# Altium schematic sheet P15-EXP_IO.SchDoc
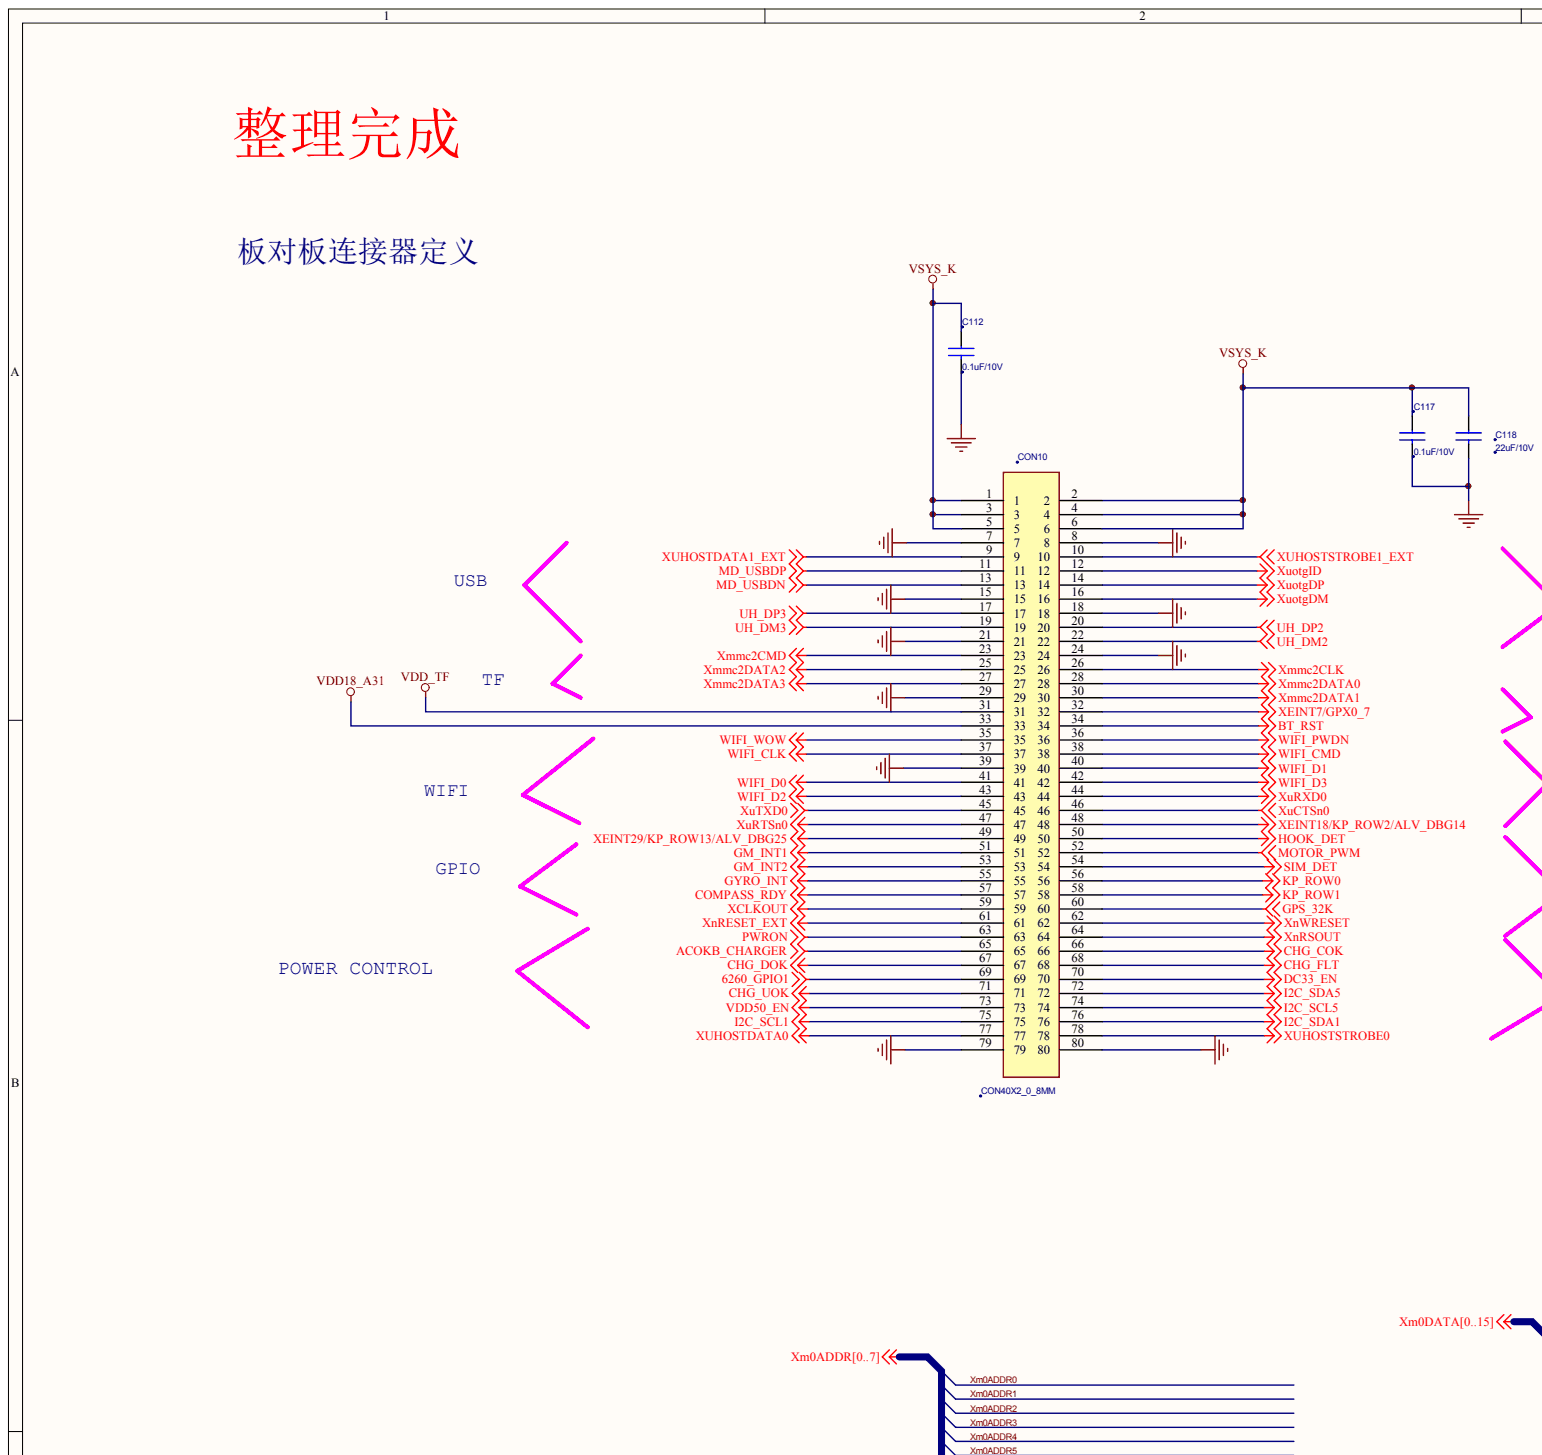
[diagram p0: P15-EXP_IO.SchDoc, top left]
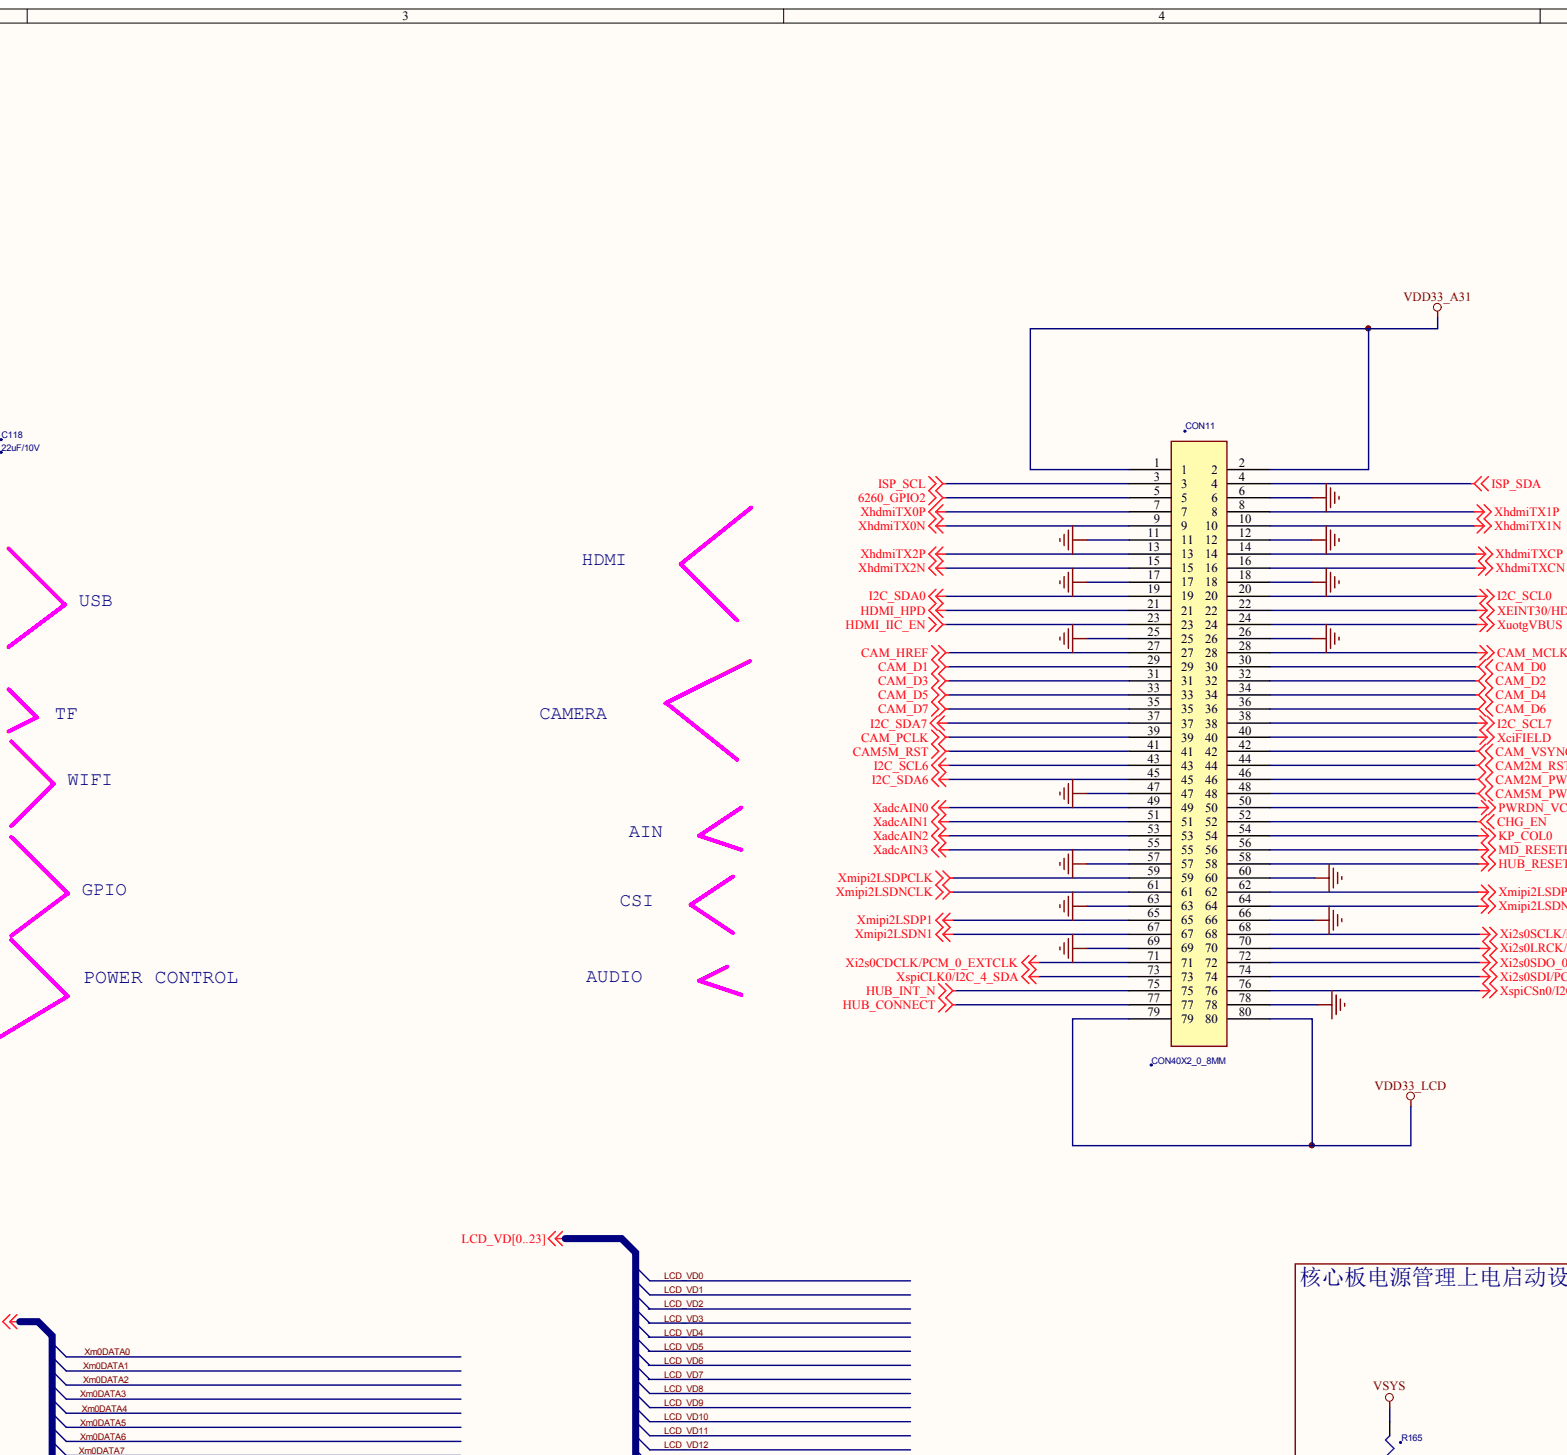
[diagram p1: P15-EXP_IO.SchDoc, top centre]
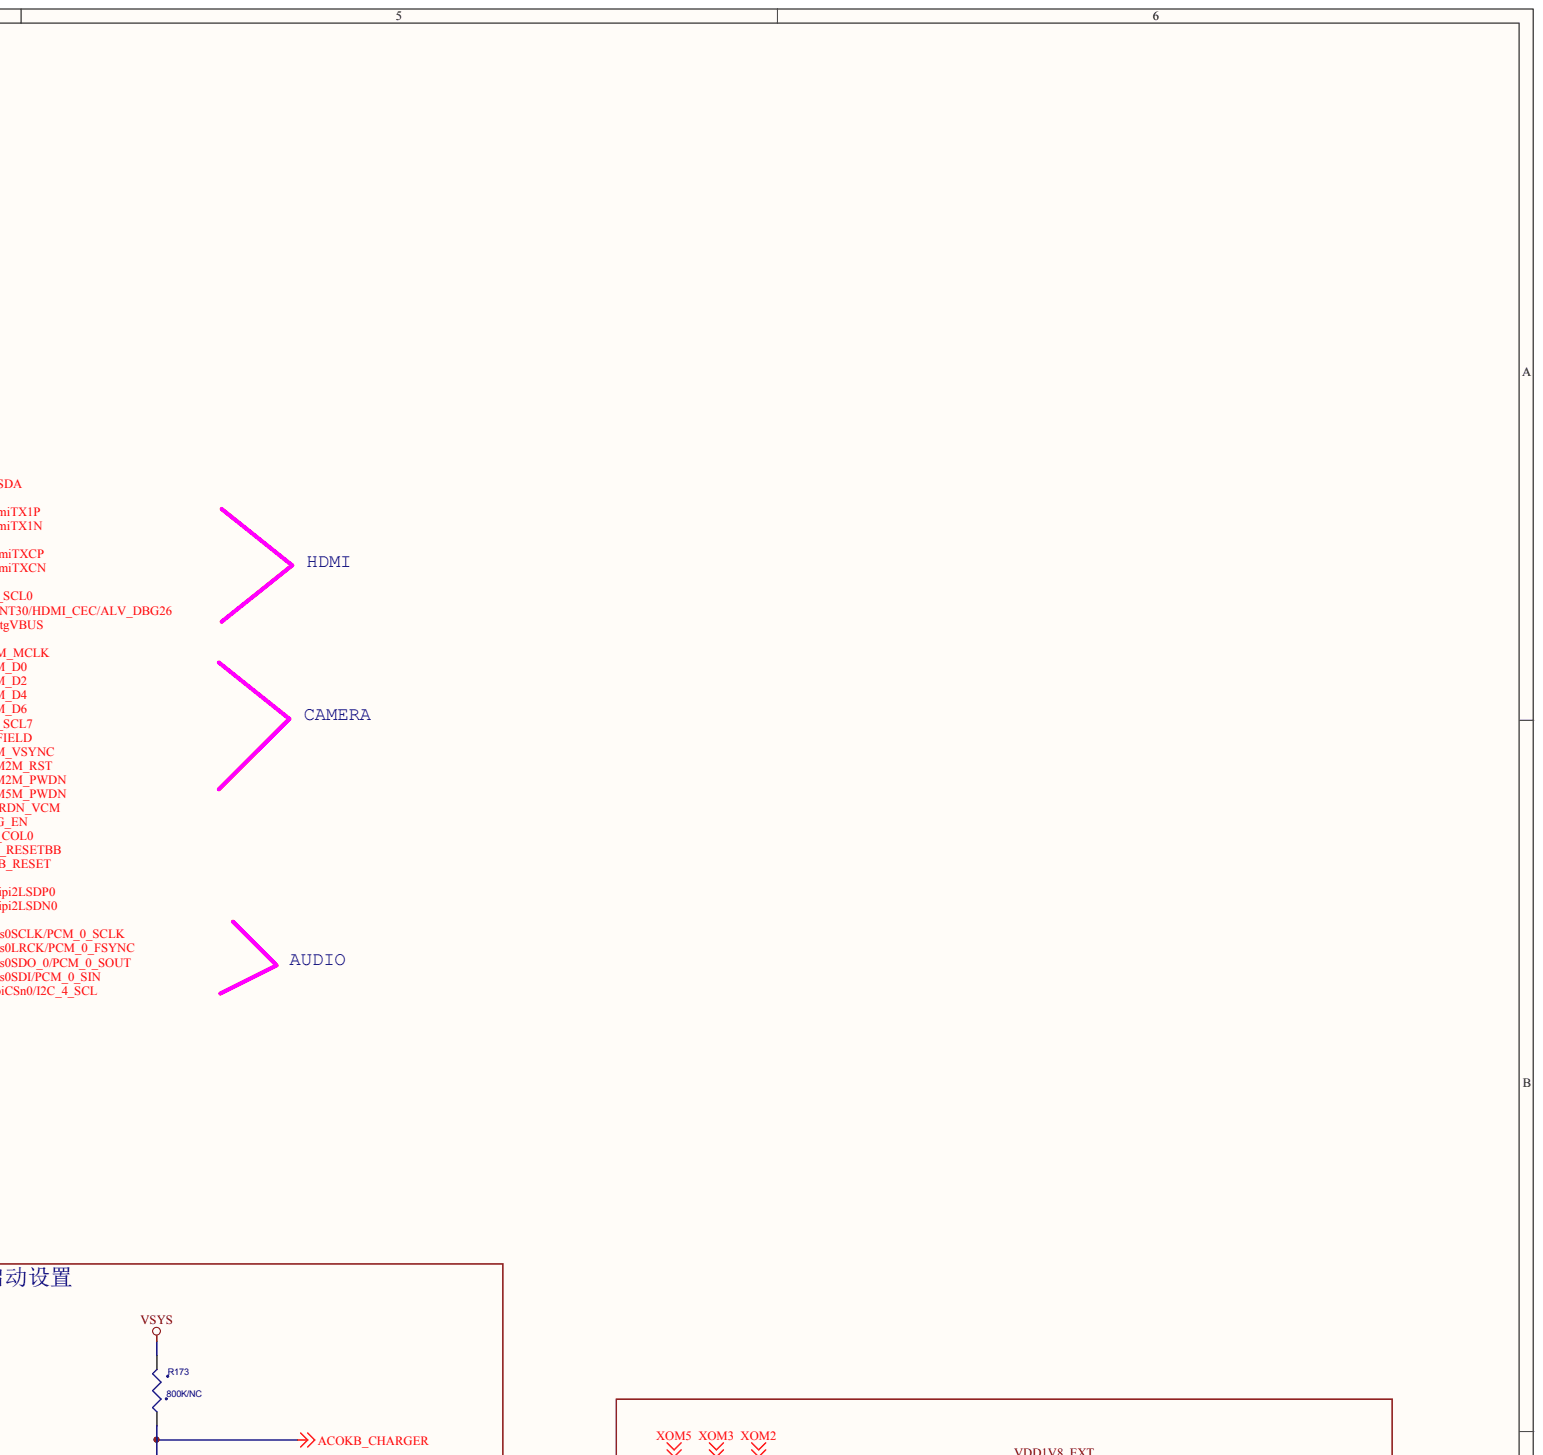
[diagram p2: P15-EXP_IO.SchDoc, top right]
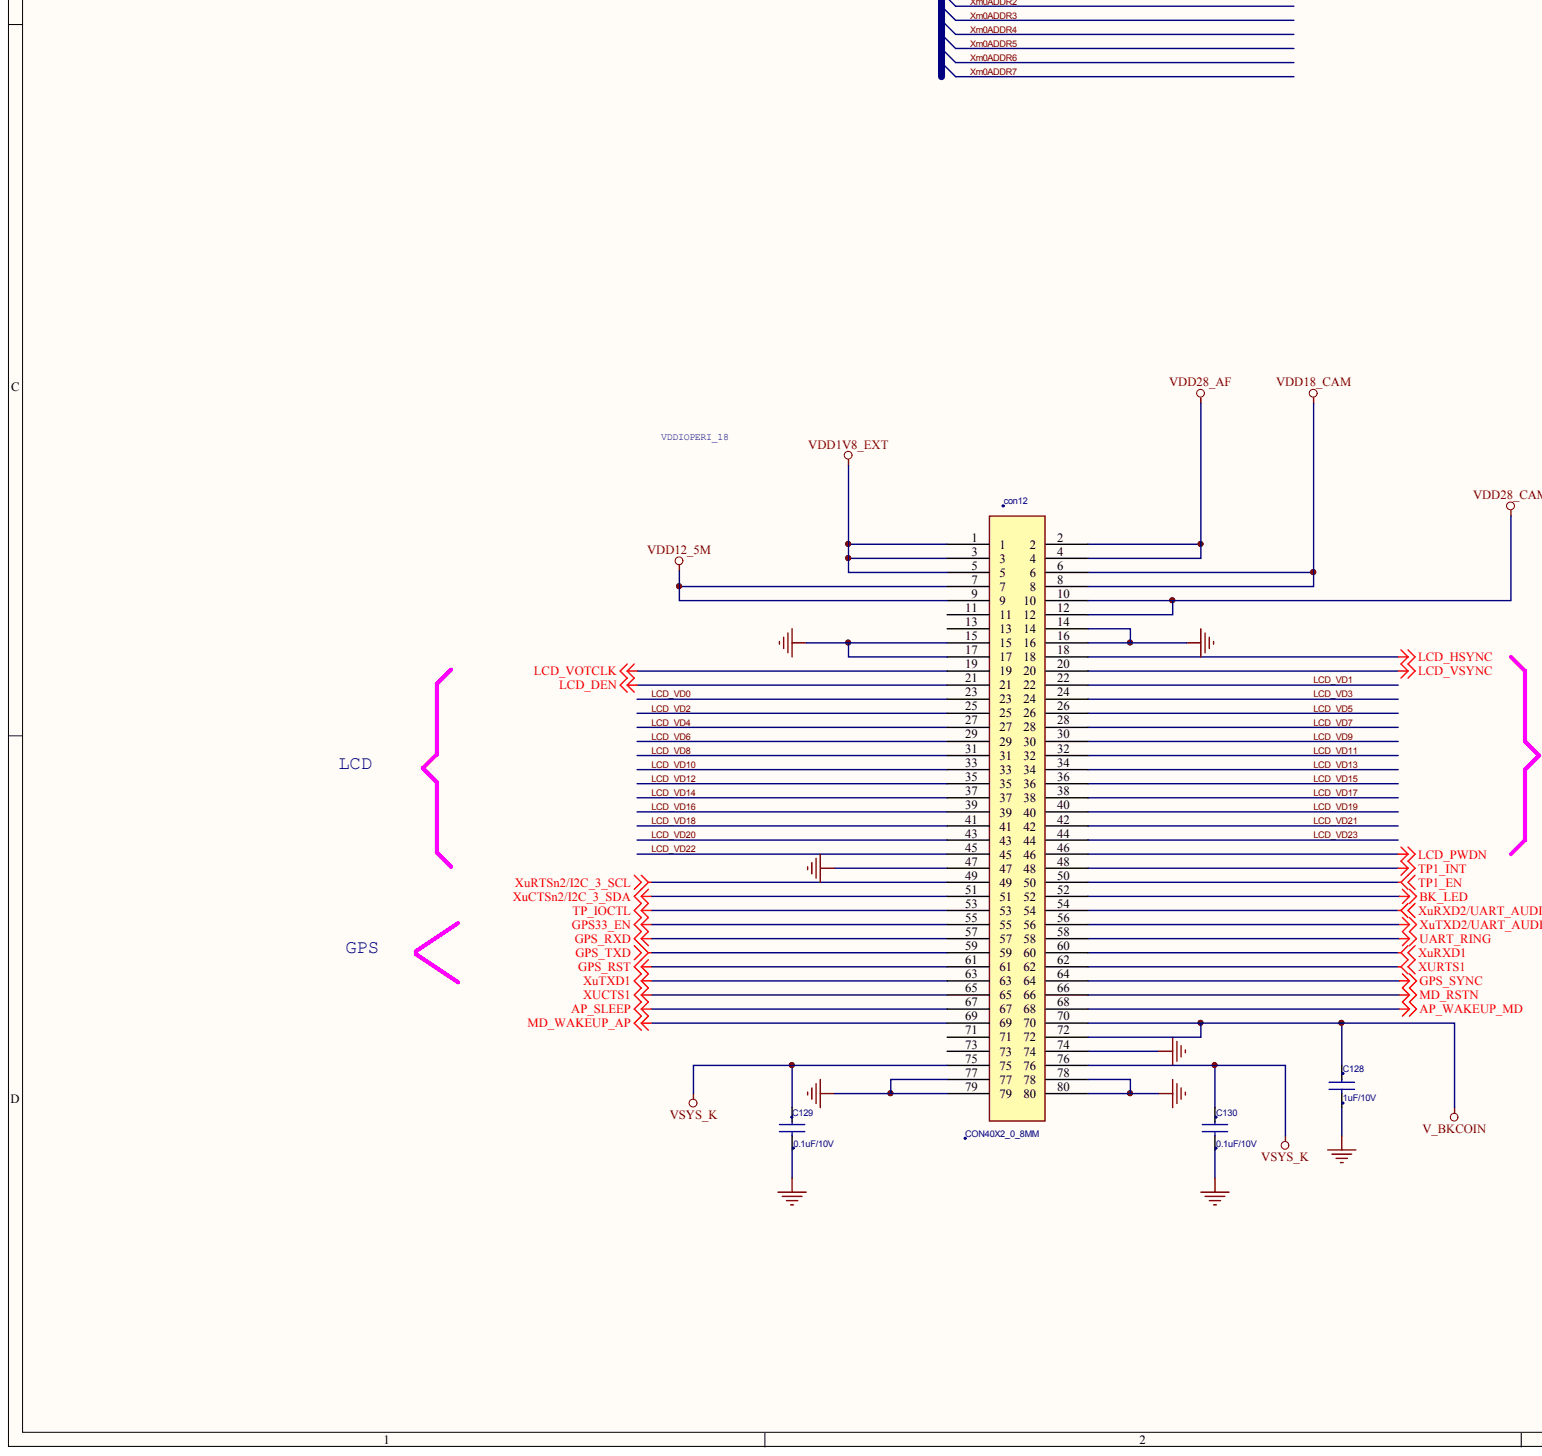
[diagram p3: P15-EXP_IO.SchDoc, bottom left]
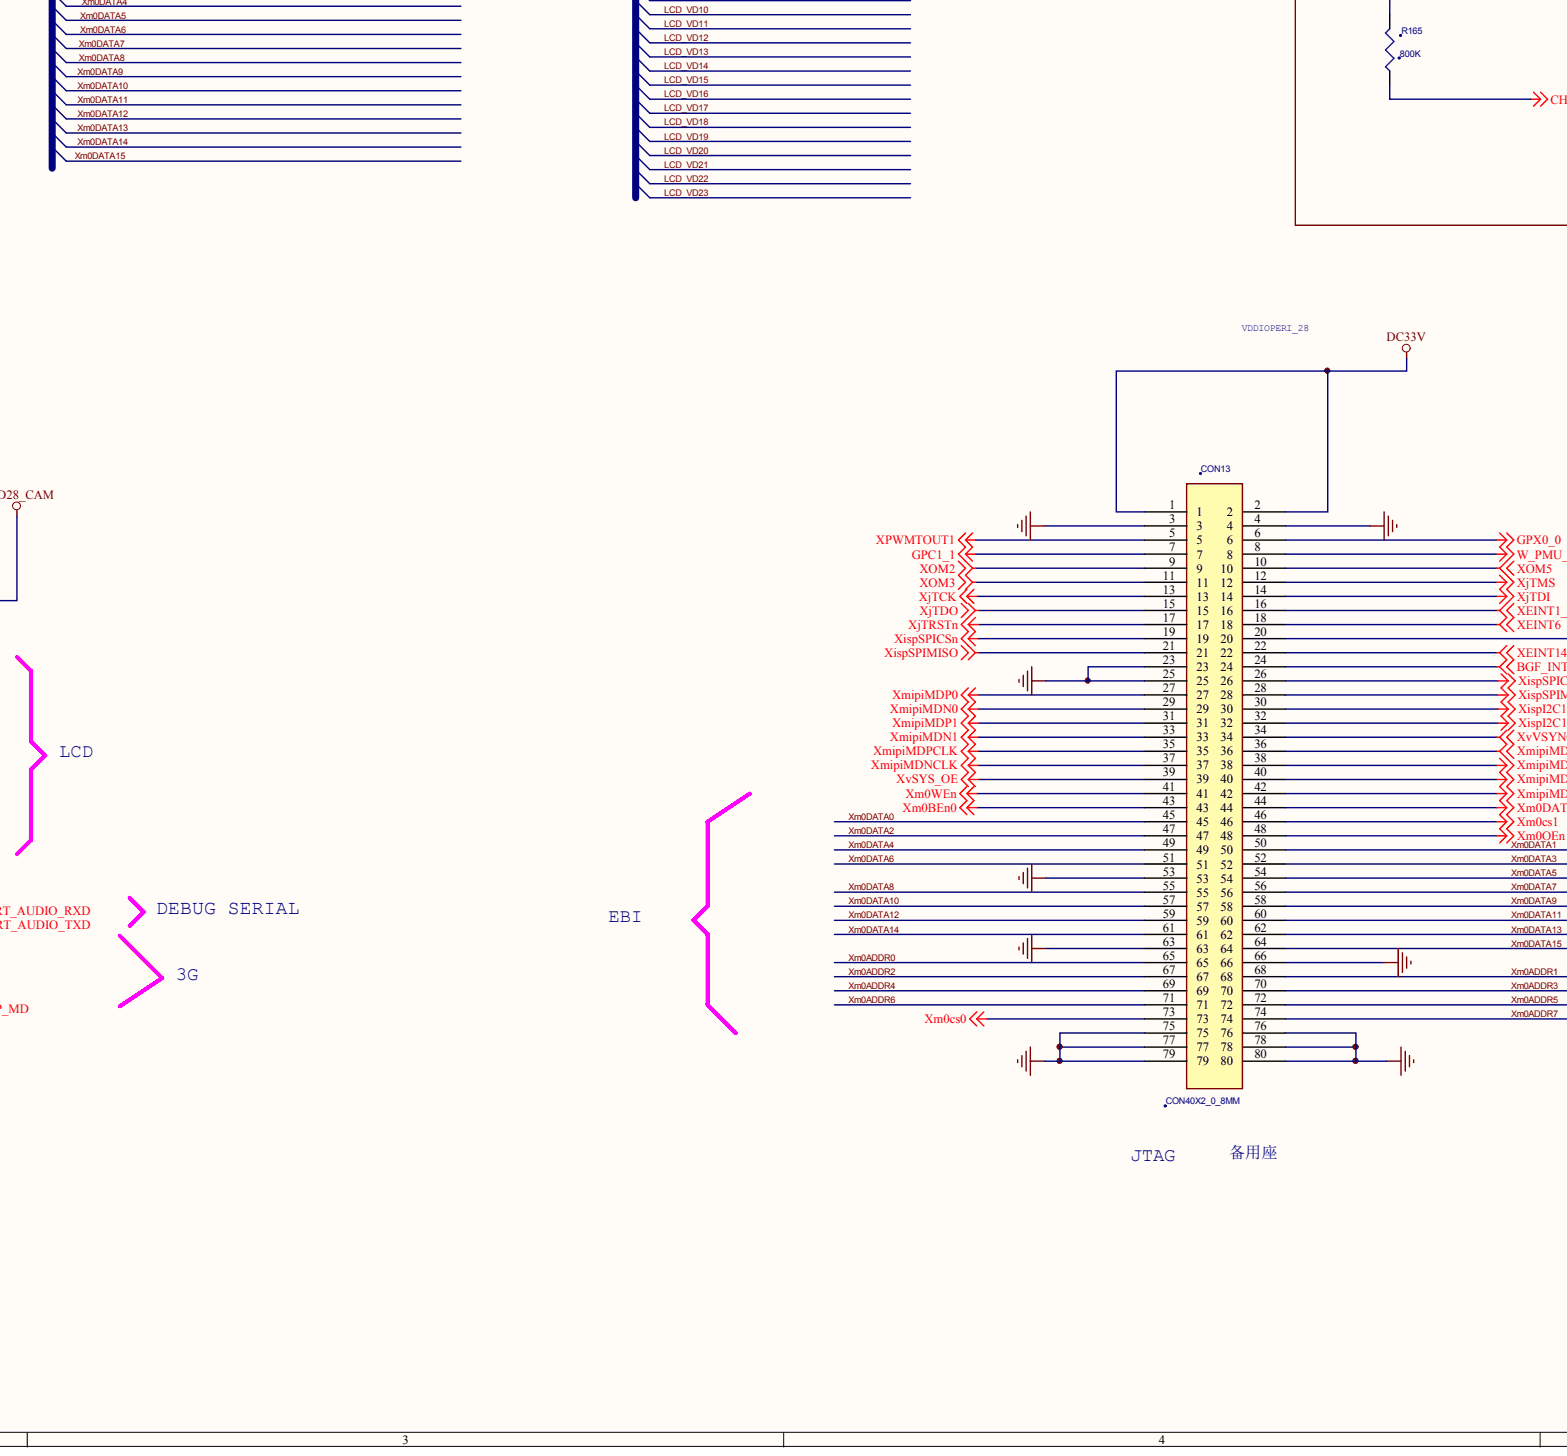
[diagram p4: P15-EXP_IO.SchDoc, bottom centre]
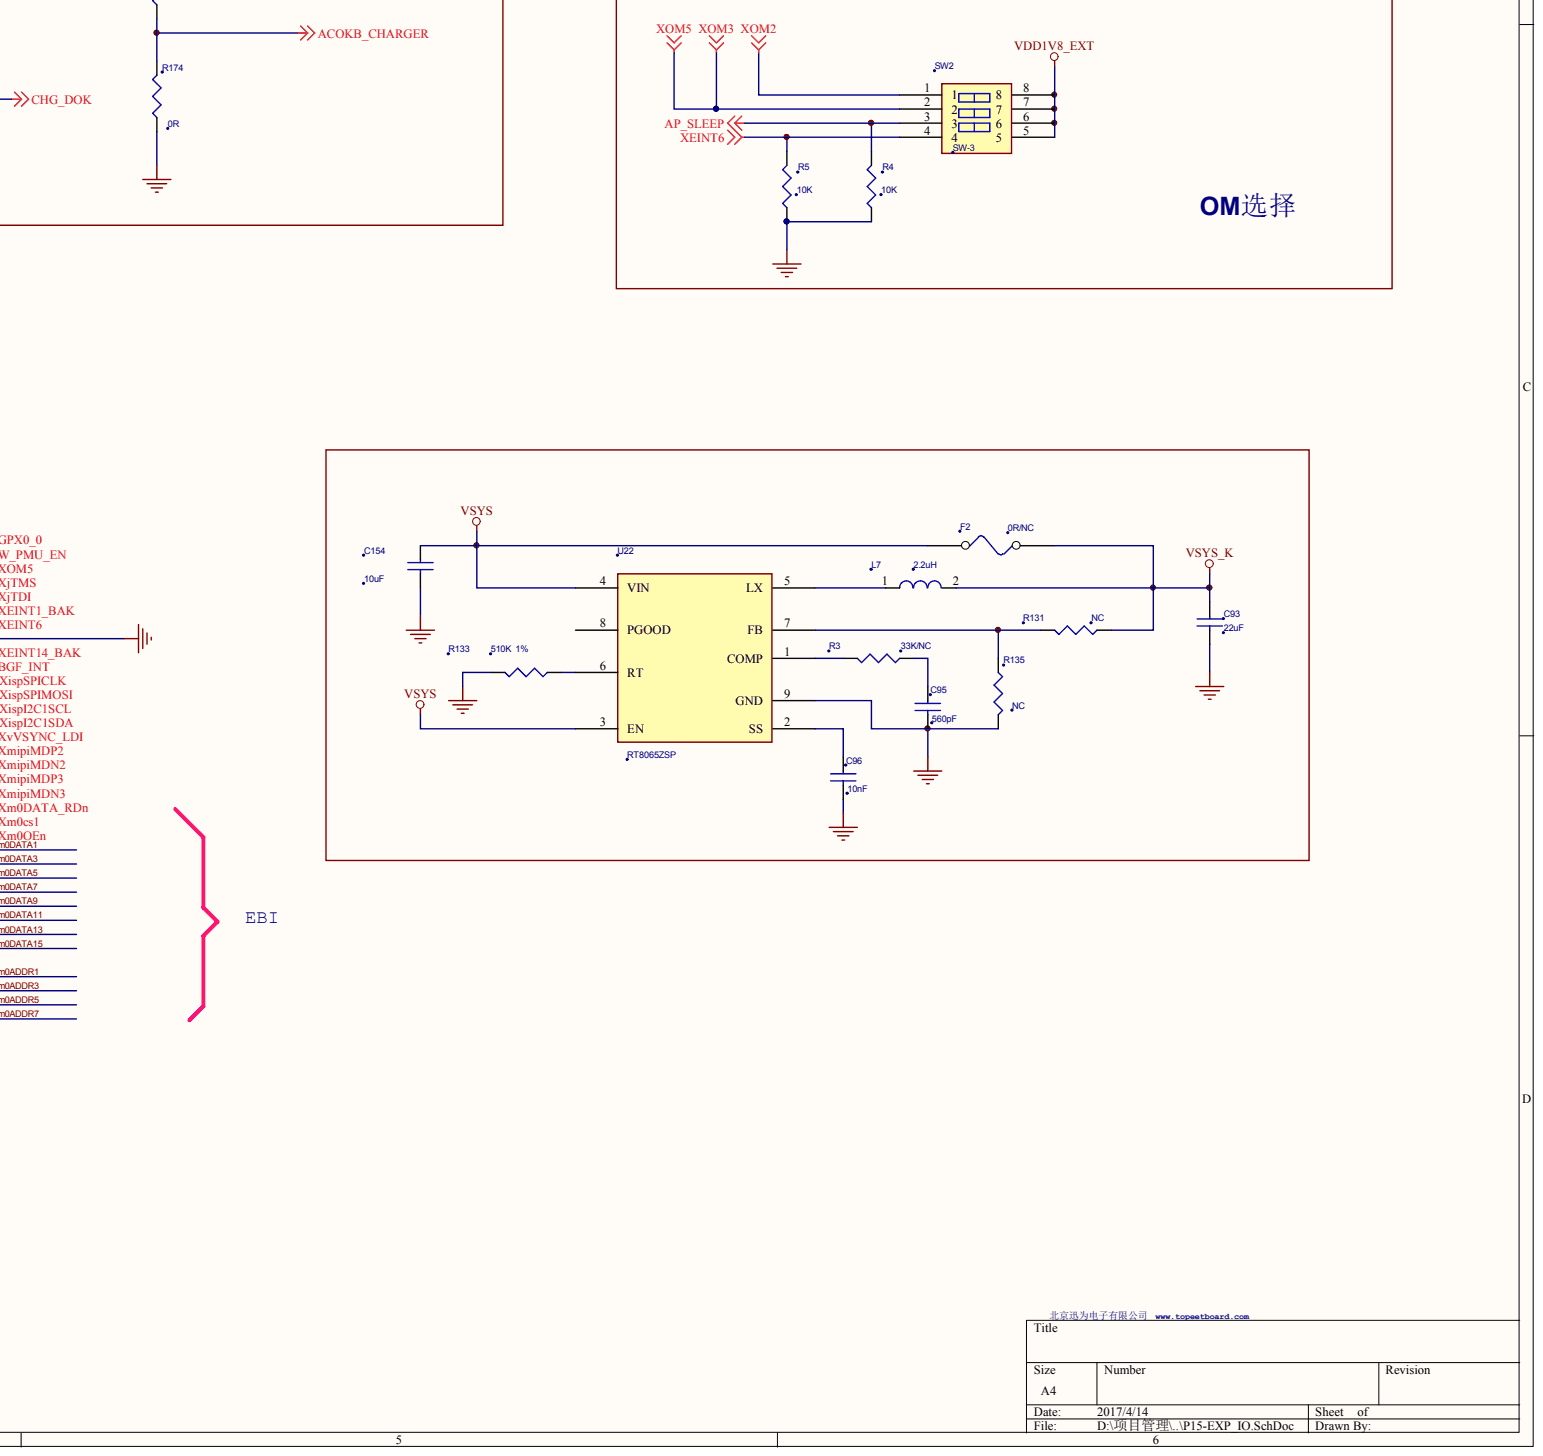
[diagram p5: P15-EXP_IO.SchDoc, bottom right]

## Parts
C93  22uF
C95  560pF
C96  10nF
C112  0.1uF/10V
C117  0.1uF/10V
C118  22uF/10V
C128  1uF/10V
C129  0.1uF/10V
C130  0.1uF/10V
C154  10uF
CON10  CON40X2_0_8MM  (value not drawn)
CON11  CON40X2_0_8MM  (value not drawn)
con12  CON40X2_0_8MM  (value not drawn)
CON13  CON40X2_0_8MM  (value not drawn)
F2  0R/NC
L7  2.2uH
R3  33K/NC
R4  10K
R5  10K
R131  NC
R133  510K  1%
R135  NC
R165  800K
R173  800K/NC
R174  0R
SW2  SW-3
U22  RT8065ZSP

## Nets
6260_GPIO1: CON10.69
6260_GPIO2: CON11.5
ACOKB_CHARGER: CON10.65 R173.1 R174.2
AP_SLEEP: con12.67 R4.2 SW2.3
AP_WAKEUP_MD: con12.68
BGF_INT: CON13.24
BK_LED: con12.52
BT_RST: CON10.34
CAM2M_PWDN: CON11.46
CAM2M_RST: CON11.44
CAM5M_PWDN: CON11.48
CAM5M_RST: CON11.41
CAM_D0: CON11.30
CAM_D1: CON11.29
CAM_D2: CON11.32
CAM_D3: CON11.31
CAM_D4: CON11.34
CAM_D5: CON11.33
CAM_D6: CON11.36
CAM_D7: CON11.35
CAM_HREF: CON11.27
CAM_MCLK: CON11.28
CAM_PCLK: CON11.39
CAM_VSYNC: CON11.42
CHG_COK: CON10.66
CHG_DOK: CON10.67 R165.1
CHG_EN: CON11.52
CHG_FLT: CON10.68
CHG_UOK: CON10.71
COMPASS_RDY: CON10.57
DC33_EN: CON10.70
DC33V: CON13.1 CON13.2
GM_INT1: CON10.51
GM_INT2: CON10.53
GND: C93.2 C95.2 C96.2 C112.2 C117.2 C118.2 C128.2 C129.2 C130.2 C154.2 CON10.7 CON10.8 CON10.15 CON10.18 CON10.21 CON10.24 CON10.29 CON10.39 CON10.79 CON10.80 CON11.6 CON11.11 CON11.12 CON11.17 CON11.18 CON11.25 CON11.26 CON11.47 CON11.57 CON11.60 CON11.63 CON11.66 CON11.69 CON11.78 con12.14 con12.15 con12.16 con12.17 con12.47 con12.74 con12.77 con12.78 con12.79 con12.80 CON13.3 CON13.4 CON13.20 CON13.23 CON13.25 CON13.53 CON13.63 CON13.66 CON13.75 CON13.76 CON13.77 CON13.78 CON13.79 CON13.80 R4.1 R5.1 R133.2 R135.2 R174.1 U22.9(GND)
GPC1_1: CON13.7
GPS33_EN: con12.55
GPS_32K: CON10.60
GPS_RST: con12.61
GPS_RXD: con12.57
GPS_SYNC: con12.64
GPS_TXD: con12.59
GPX0_0: CON13.6
GYRO_INT: CON10.55
HDMI_HPD: CON11.21
HDMI_IIC_EN: CON11.23
HOOK_DET: CON10.50
HUB_CONNECT: CON11.77
HUB_INT_N: CON11.75
HUB_RESET: CON11.58
I2C_SCL0: CON11.20
I2C_SCL1: CON10.75
I2C_SCL5: CON10.74
I2C_SCL6: CON11.43
I2C_SCL7: CON11.38
I2C_SDA0: CON11.19
I2C_SDA1: CON10.76
I2C_SDA5: CON10.72
I2C_SDA6: CON11.45
I2C_SDA7: CON11.37
ISP_SCL: CON11.3
ISP_SDA: CON11.4
KP_COL0: CON11.54
KP_ROW0: CON10.56
KP_ROW1: CON10.58
LCD_DEN: con12.21
LCD_HSYNC: con12.18
LCD_PWDN: con12.46
LCD_VOTCLK: con12.19
LCD_VSYNC: con12.20
MD_RESETBB: CON11.56
MD_RSTN: con12.66
MD_USBDN: CON10.13
MD_USBDP: CON10.11
MD_WAKEUP_AP: con12.69
MOTOR_PWM: CON10.52
PWRDN_VCM: CON11.50
PWRON: CON10.63
SIM_DET: CON10.54
TP1_EN: con12.50
TP1_INT: con12.48
TP_IOCTL: con12.53
UART_RING: con12.58
UH_DM2: CON10.22
UH_DM3: CON10.19
UH_DP2: CON10.20
UH_DP3: CON10.17
V_BKCOIN: C128.1 con12.70 con12.72
VDD1V8_EXT: con12.1 con12.3 con12.5 SW2.5 SW2.6 SW2.7 SW2.8
VDD12_5M: con12.7 con12.9
VDD18_A31: CON10.33
VDD18_CAM: con12.6 con12.8
VDD28_AF: con12.2 con12.4
VDD28_CAM: con12.10 con12.12
VDD33_A31: CON11.1 CON11.2
VDD33_LCD: CON11.79 CON11.80
VDD50_EN: CON10.73
VDD_TF: CON10.31
VSYS: C154.1 F2.1 R165.2 R173.2 U22.3(EN) U22.4(VIN)
VSYS_K: C93.1 C112.1 C117.1 C118.1 C129.1 C130.1 CON10.1 CON10.2 CON10.3 CON10.4 CON10.5 CON10.6 con12.75 con12.76 F2.2 L7.2 R131.1
W_PMU_EN: CON13.8
WIFI_CLK: CON10.37
WIFI_CMD: CON10.38
WIFI_D0: CON10.41
WIFI_D1: CON10.40
WIFI_D2: CON10.43
WIFI_D3: CON10.42
WIFI_PWDN: CON10.36
WIFI_WOW: CON10.35
XADCAIN0: CON11.49
XADCAIN1: CON11.51
XADCAIN2: CON11.53
XADCAIN3: CON11.55
XCIFIELD: CON11.40
XCLKOUT: CON10.59
XEINT1_BAK: CON13.16
XEINT6: CON13.18 R5.2 SW2.4
XEINT7/GPX0_7: CON10.32
XEINT14_BAK: CON13.22
XEINT18/KP_ROW2/ALV_DBG14: CON10.48
XEINT29/KP_ROW13/ALV_DBG25: CON10.49
XEINT30/HDMI_CEC/ALV_DBG26: CON11.22
XHDMITX0N: CON11.9
XHDMITX0P: CON11.7
XHDMITX1N: CON11.10
XHDMITX1P: CON11.8
XHDMITX2N: CON11.15
XHDMITX2P: CON11.13
XHDMITXCN: CON11.16
XHDMITXCP: CON11.14
XI2S0CDCLK/PCM_0_EXTCLK: CON11.71
XI2S0LRCK/PCM_0_FSYNC: CON11.70
XI2S0SCLK/PCM_0_SCLK: CON11.68
XI2S0SDI/PCM_0_SIN: CON11.74
XI2S0SDO_0/PCM_0_SOUT: CON11.72
XISPI2C1SCL: CON13.30
XISPI2C1SDA: CON13.32
XISPSPICLK: CON13.26
XISPSPICSN: CON13.19
XISPSPIMISO: CON13.21
XISPSPIMOSI: CON13.28
XJTCK: CON13.13
XJTDI: CON13.14
XJTDO: CON13.15
XJTMS: CON13.12
XJTRSTN: CON13.17
XM0BEN0: CON13.43
XM0CS0: CON13.73
XM0CS1: CON13.46
XM0DATA_RDN: CON13.44
XM0OEN: CON13.48
XM0WEN: CON13.41
XMIPI2LSDN0: CON11.64
XMIPI2LSDN1: CON11.67
XMIPI2LSDNCLK: CON11.61
XMIPI2LSDP0: CON11.62
XMIPI2LSDP1: CON11.65
XMIPI2LSDPCLK: CON11.59
XMIPIMDN0: CON13.29
XMIPIMDN1: CON13.33
XMIPIMDN2: CON13.38
XMIPIMDN3: CON13.42
XMIPIMDNCLK: CON13.37
XMIPIMDP0: CON13.27
XMIPIMDP1: CON13.31
XMIPIMDP2: CON13.36
XMIPIMDP3: CON13.40
XMIPIMDPCLK: CON13.35
XMMC2CLK: CON10.26
XMMC2CMD: CON10.23
XMMC2DATA0: CON10.28
XMMC2DATA1: CON10.30
XMMC2DATA2: CON10.25
XMMC2DATA3: CON10.27
XNRESET_EXT: CON10.61
XNRSOUT: CON10.64
XNWRESET: CON10.62
XOM2: CON13.9 SW2.1
XOM3: CON13.10 CON13.11 SW2.2
XPWMTOUT1: CON13.5
XSPICLK0/I2C_4_SDA: CON11.73
XSPICSN0/I2C_4_SCL: CON11.76
XUCTS1: con12.65
XUCTSN0: CON10.46
XUCTSN2/I2C_3_SDA: con12.51
XUHOSTDATA0: CON10.77
XUHOSTDATA1_EXT: CON10.9
XUHOSTSTROBE0: CON10.78
XUHOSTSTROBE1_EXT: CON10.10
XUOTGDM: CON10.16
XUOTGDP: CON10.14
XUOTGID: CON10.12
XUOTGVBUS: CON11.24
XURTS1: con12.62
XURTSN0: CON10.47
XURTSN2/I2C_3_SCL: con12.49
XURXD0: CON10.44
XURXD1: con12.60
XURXD2/UART_AUDIO_RXD: con12.54
XUTXD0: CON10.45
XUTXD1: con12.63
XUTXD2/UART_AUDIO_TXD: con12.56
XVSYS_OE: CON13.39
XVVSYNC_LDI: CON13.34
LCD_VD0: con12.23  [also labelled LCD_VD]
LCD_VD1: con12.22  [also labelled LCD_VD]
LCD_VD2: con12.25  [also labelled LCD_VD]
LCD_VD3: con12.24  [also labelled LCD_VD]
LCD_VD4: con12.27  [also labelled LCD_VD]
LCD_VD5: con12.26  [also labelled LCD_VD]
LCD_VD6: con12.29  [also labelled LCD_VD]
LCD_VD7: con12.28  [also labelled LCD_VD]
LCD_VD8: con12.31  [also labelled LCD_VD]
LCD_VD9: con12.30  [also labelled LCD_VD]
LCD_VD10: con12.33  [also labelled LCD_VD]
LCD_VD11: con12.32  [also labelled LCD_VD]
LCD_VD12: con12.35  [also labelled LCD_VD]
LCD_VD13: con12.34  [also labelled LCD_VD]
LCD_VD14: con12.37  [also labelled LCD_VD]
LCD_VD15: con12.36  [also labelled LCD_VD]
LCD_VD16: con12.39  [also labelled LCD_VD]
LCD_VD17: con12.38  [also labelled LCD_VD]
LCD_VD18: con12.41  [also labelled LCD_VD]
LCD_VD19: con12.40  [also labelled LCD_VD]
LCD_VD20: con12.43  [also labelled LCD_VD]
LCD_VD21: con12.42  [also labelled LCD_VD]
LCD_VD22: con12.45  [also labelled LCD_VD]
LCD_VD23: con12.44  [also labelled LCD_VD]
XM0ADDR0: CON13.65  [also labelled XM0ADDR]
XM0ADDR1: CON13.68  [also labelled XM0ADDR]
XM0ADDR2: CON13.67  [also labelled XM0ADDR]
XM0ADDR3: CON13.70  [also labelled XM0ADDR]
XM0ADDR4: CON13.69  [also labelled XM0ADDR]
XM0ADDR5: CON13.72  [also labelled XM0ADDR]
XM0ADDR6: CON13.71  [also labelled XM0ADDR]
XM0ADDR7: CON13.74  [also labelled XM0ADDR]
XM0DATA0: CON13.45  [also labelled XM0DATA]
XM0DATA1: CON13.50  [also labelled XM0DATA]
XM0DATA2: CON13.47  [also labelled XM0DATA]
XM0DATA3: CON13.52  [also labelled XM0DATA]
XM0DATA4: CON13.49  [also labelled XM0DATA]
XM0DATA5: CON13.54  [also labelled XM0DATA]
XM0DATA6: CON13.51  [also labelled XM0DATA]
XM0DATA7: CON13.56  [also labelled XM0DATA]
XM0DATA8: CON13.55  [also labelled XM0DATA]
XM0DATA9: CON13.58  [also labelled XM0DATA]
XM0DATA10: CON13.57  [also labelled XM0DATA]
XM0DATA11: CON13.60  [also labelled XM0DATA]
XM0DATA12: CON13.59  [also labelled XM0DATA]
XM0DATA13: CON13.62  [also labelled XM0DATA]
XM0DATA14: CON13.61  [also labelled XM0DATA]
XM0DATA15: CON13.64  [also labelled XM0DATA]
NetC95_1: C95.1 R3.1
NetC96_1: C96.1 U22.2(SS)
NetL7_1: L7.1 U22.5(LX)
NetR3_2: R3.2 U22.1(COMP)
NetR131_2: R131.2 R135.1 U22.7(FB)
NetR133_1: R133.1 U22.6(RT)

Not connected: con12 11 13 71 73; U22 8(PGOOD)

## Library data of the parts
C95 = (no symbol name): MANUFACTURER_PN: LDK105BJ105KV-F; MANUFACTURER_NAME: TAIYOYUDEN; PART_TYPE: P06-Cer CAP; USI_PN: 06-210594-01; USI_Description: CER CHIP 1U 10% X5R 0402 10V  L/F; GREEN_STATUS: Green Part; TW_MM_PLANT: 1130;1120;1110;1140; SH_MM_PLANT: 1510,1511,1520,1521; SZ_MM_PLANT: 1240,1210,1260; SH_LEAD_TIME: 56; SH_UNITCOST: 0.034128632; SZ_UNITCOST: 0.024593249; SH_INVENTORY: 10000.000; SZ_INVENTORY: 0; REMARK: Update on 20090523; INVENTORY: 160698.000; UNITCOST: 0.006868; ROOM: BACKUP_BATT; BOM_GRP: PWR_BACKUP-BATT; SZ_LEAD_TIME: 56; SH_LASTBUY_DATE: 2009-03-31; SZ_LASTBUY_DATE: 2009-04-01; LEAD_TIME: 56; LASTBUY_DATE: 2007-07-01; LIFECYCLE: AMPL2; DATA_SHEET: http://pdmas.usi.com.tw/pdm?url=http://pdmap.usi.com.tw/Agile/PLMServlet?action=OpenEmailObject&classid=10000&objid=140990334; FOOTPRINT_LINK: smd_0402_cap.dra; PART_NUMBER: 06-210594-01; Component Type: 1UF; CHE: 0
C96 C154 = (no symbol name): PART_NUMBER: 06-210594-01; PART_TYPE: P06-Cer CAP; MANUFACTURER_NAME: TAIYOYUDEN; MANUFACTURER_PN: LDK105BJ105KV-F; BOM_GRP: PWR_BACKUP-BATT; ROOM: BACKUP_BATT; CHE: 0; UNITCOST: 0.006868; INVENTORY: 160698.000; LEAD_TIME: 56; REMARK: Update on 20090523; SH_INVENTORY: 10000.000; SZ_UNITCOST: 0.024593249; SH_UNITCOST: 0.034128632; SZ_MM_PLANT: 1240,1210,1260; SH_MM_PLANT: 1510,1511,1520,1521; TW_MM_PLANT: 1130;1120;1110;1140; GREEN_STATUS: Green Part; USI_Description: CER CHIP 1U 10% X5R 0402 10V  L/F; Component Type: 1UF; FOOTPRINT_LINK: smd_0402_cap.dra; DATA_SHEET: http://pdmas.usi.com.tw/pdm?url=http://pdmap.usi.com.tw/Agile/PLMServlet?action=OpenEmailObject&classid=10000&objid=140990334; LIFECYCLE: AMPL2; LASTBUY_DATE: 2007-07-01; SZ_INVENTORY: 0; SZ_LASTBUY_DATE: 2009-04-01; SH_LASTBUY_DATE: 2009-03-31; SZ_LEAD_TIME: 56; SH_LEAD_TIME: 56; USI_PN: 06-210594-01
